# Altium schematic sheet Audio.SchDoc(Audio)
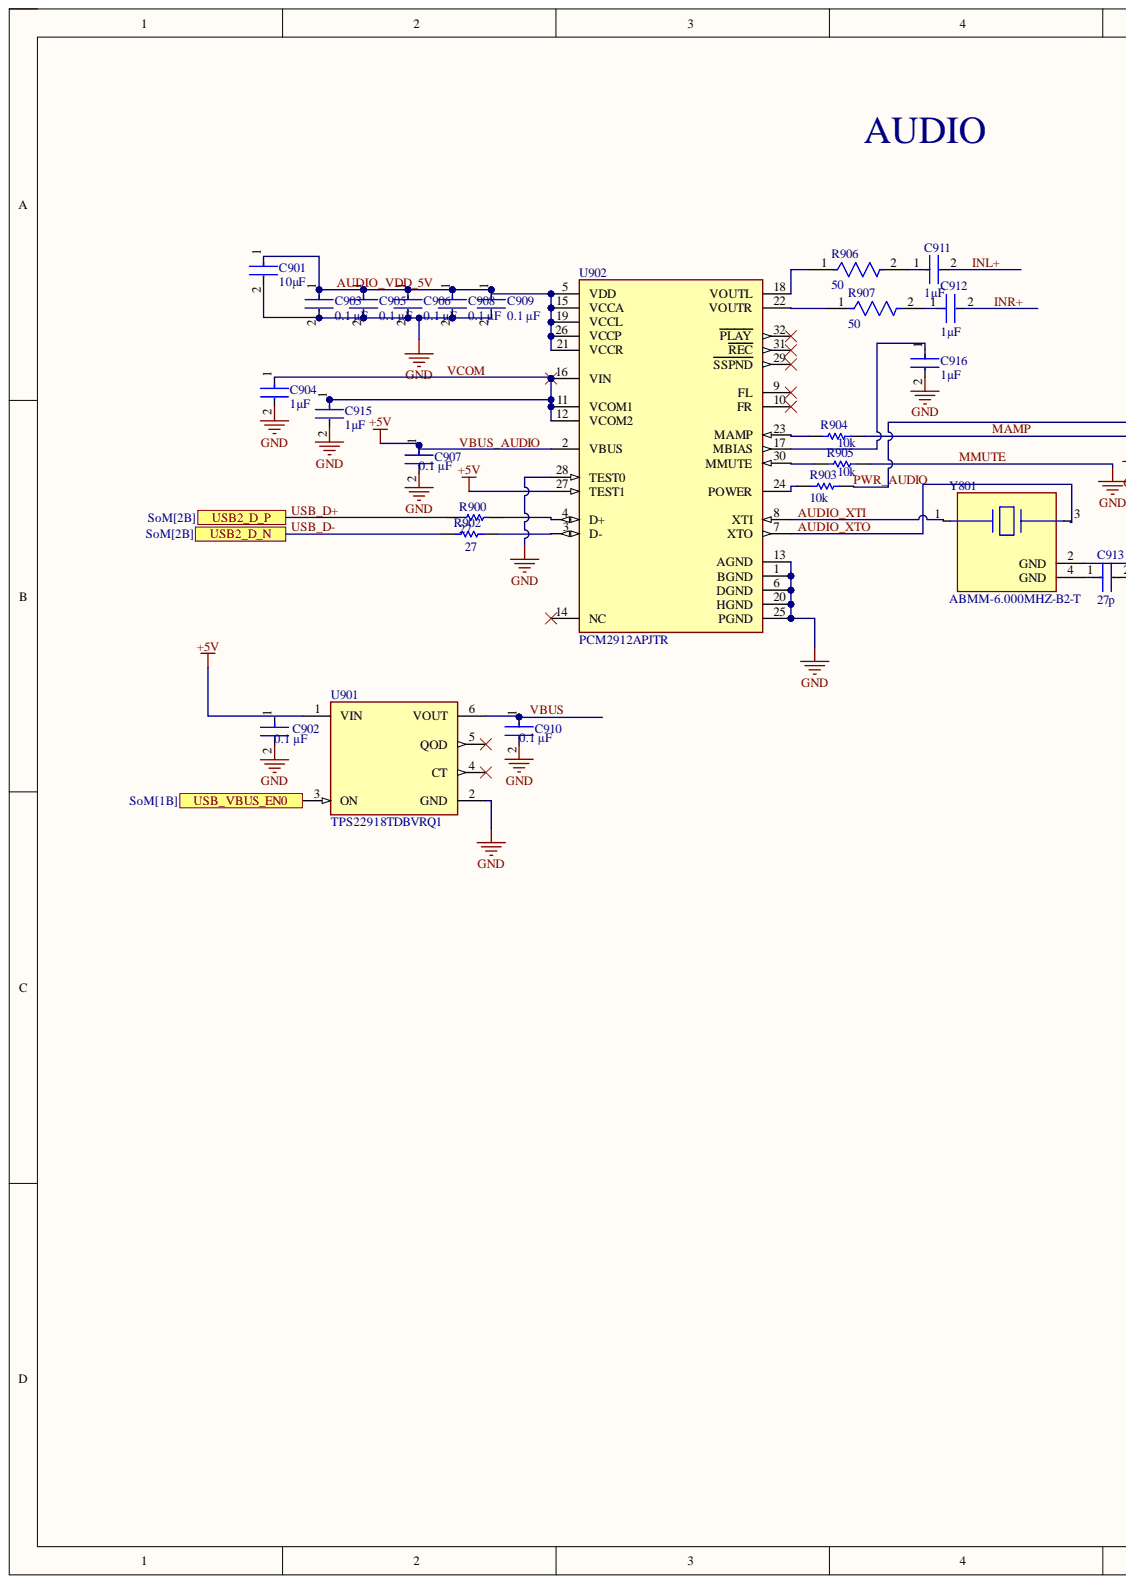
[diagram p0: Audio.SchDoc, left]
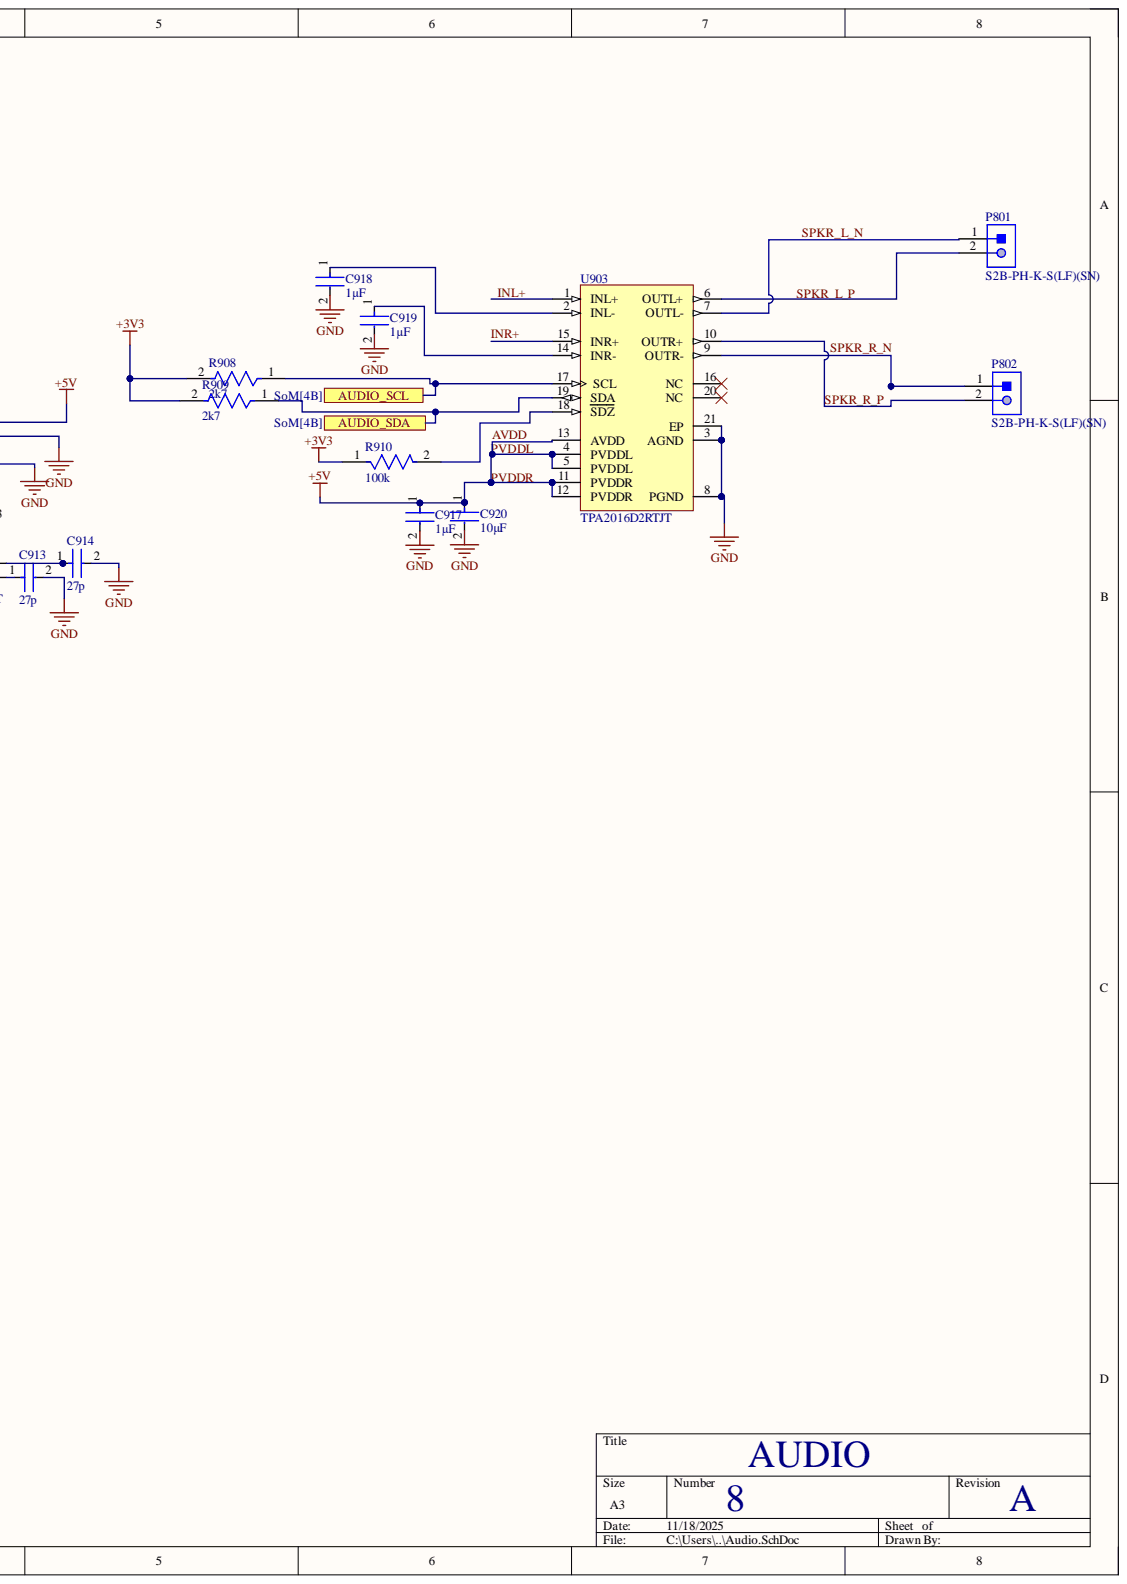
[diagram p1: Audio.SchDoc, right]

## Parts
C901  10μF  [FP-GRM31C-0_2-e0_3_0_8-MFG]
C902  0.1 µF  [FP-GRM155-0_05-IPC_B]
C903  0.1 µF  [FP-GRM155-0_05-IPC_B]
C904  1µF  [FP-GRM15-0_1-MFG]
C905  0.1 µF  [FP-GRM155-0_05-IPC_B]
C906  0.1 µF  [FP-GRM155-0_05-IPC_B]
C907  0.1 µF  [FP-GRM155-0_05-IPC_B]
C908  0.1 µF  [FP-GRM155-0_05-IPC_B]
C909  0.1 µF  [FP-GRM155-0_05-IPC_B]
C910  0.1 µF  [FP-GRM155-0_05-IPC_B]
C911  1µF  [FP-GRM15-0_1-MFG]
C912  1µF  [FP-GRM15-0_1-MFG]
C913  27p  [FP-C0603C-CF-MFG]
C914  27p  [FP-C0603C-CF-MFG]
C915  1µF  [FP-GRM15-0_1-MFG]
C916  1µF  [FP-GRM15-0_1-MFG]
C917  1µF  [FP-GRM15-0_1-MFG]
C918  1µF  [FP-GRM15-0_1-MFG]
C919  1µF  [FP-GRM15-0_1-MFG]
C920  10μF  [FP-GRM31C-0_2-e0_3_0_8-MFG]
P801  S2B-PH-K-S(LF)(SN)  [JST-S2B-PH-K-S_V]
P802  S2B-PH-K-S(LF)(SN)  [JST-S2B-PH-K-S_V]
R900  27  [RESC1005X40X25LL05T10]
R902  27  [RESC1005X40X25LL05T10]
R903  10k  [RESC1005X40X25LL05T10]
R904  10k  [RESC1005X40X25LL05T10]
R905  10k  [RESC1005X40X25LL05T10]
R906  50  [FP-CRCW0603-e3-MFG]
R907  50  [FP-CRCW0603-e3-MFG]
R908  2k7  [FP-CR0402-IPC_B]
R909  2k7  [FP-CR0402-IPC_B]
R910  100k  [FP-RC0402-0_4-IPC_A]
U901  TPS22918TDBVRQ1  [FP-DBV0006A-IPC_C]
U902  PCM2912APJTR  [FP-PJT0032A-IPC_C]
U903  TPA2016D2RTJT  [RTJ0020D_V]
Y801  ABMM-6.000MHZ-B2-T  [FP-ABMM-MFG]

## Ports
AUDIO_SCL, AUDIO_SDA, USB2_D_N, USB2_D_P, USB_VBUS_EN0

## Nets
+3V3: R908.2 R909.2 R910.1
AUDIO_SCL: R908.1 U903.17(SCL) ; port AUDIO_SCL
AUDIO_SDA: R909.1 U903.19(SDA) ; port AUDIO_SDA
AUDIO_VDD_5V: C901.1 C903.1 C905.1 C906.1 C908.1 C909.1 U902.5(VDD) U902.15(VCCA) U902.19(VCCL) U902.21(VCCR) U902.26(VCCP)
AUDIO_XTI: U902.8(XTI) Y801.1
AUDIO_XTO: U902.7(XTO) Y801.3
AVDD: C917.1 C920.1 R903.1 U901.1(VIN) U902.27(TEST1) U903.4(PVDDL) U903.5(PVDDL) U903.11(PVDDR) U903.12(PVDDR) U903.13(AVDD)
INL+: C911.2 U903.1(INL+)
INR+: C912.2 U903.15(INR+)
MAMP: C901.2 C902.2 C903.2 C904.2 C905.2 C906.2 C907.2 C908.2 C909.2 C910.2 C913.2 C914.2 C915.2 C916.2 C917.2 C918.2 C919.2 C920.2 R904.1 R905.1 U901.2(GND) U902.1(BGND) U902.6(DGND) U902.13(AGND) U902.20(HGND) U902.25(PGND) U902.28(TEST0) U903.3(AGND) U903.8(PGND) U903.21(EP)
SPKR_L_N: P801.1 U903.7(OUTL-)
SPKR_R_N: P802.1 U903.9(OUTR-)
SPKR_R_P: P802.2 U903.10(OUTR+)
USB_D+: R900.1 ; port USB2_D_P
USB_D-: R902.1 ; port USB2_D_N
USB_VBUS_EN0: U901.3(ON) ; port USB_VBUS_EN0
VBUS: C910.1 U901.6(VOUT)
VBUS_AUDIO: C907.1 U902.2(VBUS)
VCOM: C904.1 C915.1 U902.11(VCOM1) U902.12(VCOM2) U902.16(VIN)
NetC911_1: C911.1 R906.2
NetC912_1: C912.1 R907.2
NetC913_1: C913.1 Y801.4(GND)
NetC914_1: C914.1 Y801.2(GND)
NetC916_1: C916.1 U902.17(MBIAS)
NetC918_1: C918.1 U903.2(INL-)
NetC919_1: C919.1 U903.14(INR-)
NetP801_2: P801.2 U903.6(OUTL+)
NetR900_2: R900.2 U902.4(D+)
NetR902_2: R902.2 U902.3(D-)
NetR903_2: R903.2 U902.24(POWER)
NetR904_2: R904.2 U902.23(MAMP)
NetR905_2: R905.2 U902.30(MMUTE)
NetR906_1: R906.1 U902.18(VOUTL)
NetR907_1: R907.1 U902.22(VOUTR)
NetR910_2: R910.2 U903.18(SDZ)
SPKR_L_P:

Not connected: C902 1; U901 4(CT) 5(QOD); U902 9(FL) 10(FR) 14(NC) 29(SSPND) 31(REC) 32(PLAY); U903 16(NC) 20(NC)

## Library data of the parts
C901 C920 = CMP-06035-005515-1: Chip Multilayer Ceramic Capacitors for General Purpose, 1206, 10uF, X7R, 15%, 10%, 25V; Capacitance: 10uF; Case/Package: 1206; Component Kind: Standard; Dielectric: X7R; Lead Free: Lead Free; Length: 0.126inch; Library Name: Altium Content Vault; Max Operating Temperature: 125°C; Min Operating Temperature: -55°C; Mount: Surface Mount; Packaging: Tape and Reel; Thickness: 0.071inch; Tolerance: 10%; Voltage: 25V; Voltage Rating: 25V; Voltage Rating (DC): 25V; Width: 0.063inch
C902 C903 C905-C910 = CMP-06035-003750-1: Chip Multilayer Ceramic Capacitors for General Purpose, 0402, 0.10uF, X7R, 15%, 10%, 50V; Capacitance: 100nF; Case Code (Imperial): 0402; Case Code (Metric): 1005; Case/Package: 0402; Component Kind: Standard; Dielectric: X7R; Height: 0.5mm; Lead Free: Lead Free; Length: 0.039inch; Library Name: Altium Content Vault; Max Operating Temperature: 125°C; Min Operating Temperature: -55°C; Mount: Surface Mount; Package Quantity: 50000; Packaging: Tape and Reel; Resistor Type: Ceramic; Termination: SMD/SMT; Thickness: 0.022inch; Tolerance: 10%; Voltage Rating: 50V; Voltage Rating (DC): 50V; Weight: 0.000212oz; Width: 0.5mm
C904 C911 C912 C915-C919 = CMP-2008-04556-2: CAP CER 1UF 10V X5R 0402; Manufacturer: Murata; Manufacturer Part Number: GRM155R61A105KE15D; Material: Ceramic; Temperature Coefficient: 15%; Tolerance: 10%; Automotive: No; Capacitance: 1uF; Category: Cap; Component Kind: Standard; ComponentLink2Description: Datasheet; ComponentLink2URL: https://search.murata.co.jp/Ceramy/image/img/A01X/G101/ENG/GRM155R61A105KE15-01A.pdf; Device Class L1: Passive Components; Device Class L2: Capacitors; Device Class L3: Ceramic Capacitors; Digikey Description: CAP CER 1UF 10V X5R 0402; Height: 0.55mm; Ipc Land Pattern Name: CAPC10050X50; Lead Free: Yes; Library Name: Altium Content Vault; Mouser Description: 0402 1 uF 10 V ±10% Tolerance X5R Surface Mount Multilayer Ceramic Capacitor; Octopart: https://octopart.com/grm155r61a105ke15d-murata-197442; Package: GRM15-0.1; RoHS: TRUE; Temperature: -55°C to +85°C; Temperature Characteristic: X5R; Temperature Range High: +85°C; Temperature Range Low: -55°C; Value: 1uF; Voltage Rating: 10V
C913 C914 = CMP-03020-010139-1: C0603 27 pF X7R 30ppm/°C 5.00% 50 V; Manufacturer: KEMET; Manufacturer Part Number: C0603C270J5RACTU; Automotive Grade: Grade 1; Capacitance: 27 pF; Material: Ceramic; Tolerance: 0.05; Value: 27 pF; Voltage Rating: 50 V; Automotive: Yes; Category: Cap; Component Kind: Standard; ComponentLink2Description: Datasheet; ComponentLink2URL: https://api.kemet.com/component-edge/download/datasheet/C0603C100J5GACAUTO.pdf; Device Class L1: Passive Components; Device Class L2: Capacitors; Device Class L3: Ceramic Capacitors; Height: 0.87mm; Lead Free: Yes; Library Name: Altium Content Vault; Octopart: https://octopart.com/c0603c270j5ractu-kemet-82267354; Package: C0603C-CF; RoHS: TRUE; Temperature: -55°C to +125°C; Temperature Characteristic: X7R; Temperature Coefficient: 30ppm/°C; Temperature Range High: +125°C; Temperature Range Low: -55°C
P801 P802 = CMP-1755-00004-1: Male Header, Pitch 2 mm, 1 x 2 Position, Height 4.8 mm, Tail Length 3.4 mm, -25 to 85 degC, RoHS, Bulk; Capacitance: 10 uF; Case\Package: Radial; Tolerance: 20%; Voltage Rating: 50 V; Component Kind: Standard; ComponentLink1Description: Datasheet; ComponentLink1URL: http://www.jst-mfg.com/product/pdf/eng/ePH.pdf; DatasheetVersion: Dec-12; Diameter: 5 mm; Height: 11 mm; Lead Pitch: 2 mm; Length: 11 mm; Library Name: Altium Content Vault; Max Operating Temperature: 85 degC; Min Operating Temperature: -40 degC; Mount: Through Hole; Package Quantity: 200; PackageDescription: Male Header, Pitch 2 mm, 1 x 2 Position, Height 4.8 mm, Tail Length 3.4 mm; PackageReference: S2B-PH-K-S; Packaging: Bulk; Pins: 2; Radiation Hardening: No; REACH SVHC: No SVHC; RoHSCompliant: true; Voltage Rating (DC): 50 V
R900 R902 = CMP-2002-07055-1: Manufacturer: Yageo / Phycomp; Part Number: RC0402FR-0727RL; Component Kind: Standard; Library Name: Altium Content Vault
R903-R905 = CMP-2002-07353-1: Manufacturer: Yageo / Phycomp; Part Number: RC0402FR-0710KL; Component Kind: Standard; Library Name: Altium Content Vault
R906 R907 = CMP-2000-03436-2: RES Thick Film, 50Ω, 1%, 0.1W, 100ppm/°C, 0603; Power Rating: 100mW; Resistance: 50R; Voltage Rating: 75V; Case Code (Imperial): 0603; Case Code (Metric): 1608; Case/Package: 0603; Component Kind: Standard; Composition: Thick Film; Contact Plating: Tin; Depth: 0.85mm; Height: 0.45mm; Lead Free: Lead Free; Length: 1.55mm; Library Name: Altium Content Vault; Max Operating Temperature: 155°C; Military Standard: Not; Min Operating Temperature: -55°C; Mount: Surface Mount; Number of Pins: 2; Package Quantity: 5000; Packaging: Tape and Reel; Radiation Hardening: No; REACH SVHC: No SVHC; Temperature Coefficient: 100ppm/°C; Termination: SMD/SMT; Tolerance: 1%; Voltage Rating (DC): 75V; Weight: 7.1E-05oz; Width: 0.85mm
R908 R909 = CMP-2002-05009-2: Thick Film Chip Resistors 0402 2.7kΩ 0.0625W 1% 100ppm/°C; Max Power Dissipation: 63mW; Power Rating: 62.5mW; Resistance: 2.7kR; Voltage Rating: 50V; Case Code (Imperial): 0402; Case Code (Metric): 1005; Case/Package: 0402; Component Kind: Standard; Composition: Thick Film; Contact Plating: Tin; Depth: 0.5mm; Height: 0.016inch; Length: 1mm; Library Name: Altium Content Vault; Max Operating Temperature: 125°C; Military Standard: Not; Min Operating Temperature: -55°C; Mount: Surface Mount; Number of Terminations: 2; Package Quantity: 10000; Packaging: Tape and Reel; Radiation Hardening: No; Temperature Coefficient: 100ppm/°C; Termination: SMD/SMT; Thickness: 356um; Tolerance: 1%; Width: 0.02inch
R910 = CMP-2002-07913-2: RES 100K OHM 5% 1/16W 0402; Max Power Dissipation: 63mW; Resistance: 100kR; Temperature Coefficient: 100ppm/°C; Voltage Rating: 50V; Case Code (Imperial): 0402; Case Code (Metric): 1005; Case/Package: 0402; Component Kind: Standard; Construction: Rectangular; Depth: 0.5mm; Features: Moisture Resistant; Height: 0.016inch; Lead Free: Lead Free; Length: 1mm; Library Name: Altium Content Vault; Max Operating Temperature: 155°C; Military Standard: Not; Min Operating Temperature: -55°C; Number of Terminations: 2; Package Quantity: 1; Packaging: Tape and Reel; Power Rating: 63mW; Resistance Tolerance: 5%; Resistor Type: Thick Film; RoHS Compliant: Yes; Series: RC; Size Code: 0402; Termination: SMD/SMT; Tolerance: 5%; Width: 0.02inch
U901 = CMP-04910-000092-1: IC PWR SWITCH N-CHAN 1:1 SOT23-6; Interface: On/Off; Max Output Current: 2A; Number of Channels: 1; Output Configuration: High Side; Case/Package: SOT-23-6; Component Kind: Standard; Lead Free: Lead Free; Library Name: Altium Content Vault; Max Operating Temperature: 105°C; Min Operating Temperature: -40°C; Number of Outputs: 1; Packaging: Tape and Reel; RoHS Compliant: Yes
U902 = CMP-04873-000003-1: IC STEREO AUD CODEC W/USB 32TQFP; Interface: USB; Max Supply Voltage: 5.25V; Min Supply Voltage: 3V; Number of A/D Converters: 1; Number of Analog Outputs: 2; Operating Supply Voltage: 5V; Resolution: 16b; Sampling Rate: 48KS/s; Signal to Noise Ratio (SNR): 92dB; Case/Package: TQFP; Component Kind: Standard; Contact Plating: Gold; Lead Free: Lead Free; Library Name: Altium Content Vault; Max Operating Temperature: 85°C; Min Operating Temperature: -25°C; Mount: Surface Mount; Number of ADC Channels: 1; Number of Analog Inputs: 1; Number of D/A Converters: 1; Number of DAC Channels: 2; Number of Pins: 32; Package Quantity: 1000; Packaging: Tape and Reel; Radiation Hardening: No; RoHS Compliant: Yes; Series: -; Type: General Purpose
U903 = CMP-0991-00197-2: 2.8-W/Ch Stereo Class-D Audio Amplifier with SmartGainTM AGC / DRC, -40 to 85 degC, 20-pin QFN (RTJ20), Green (RoHS & no Sb/Br); Manufacturer: Texas Instruments; Output Power(W): 2.8; PSRR(dB): -80; SubFamily: Dynamic Speaker; Supply Max(Max)(Volt): 5.5; Supply Min(Min)(Volt): 2.5; Component Kind: Standard; ComponentLink1Description: Manufacturer URL; ComponentLink1URL: http://www.ti.com/; ComponentLink2Description: Datasheet; ComponentLink2URL: http://www.ti.com/general/docs/lit/getliterature.tsp?genericPartNumber=TPA2016D2&fileType=pdf; ComponentLink3Description: Package Specification; ComponentLink3URL: http://www.ti.com/litv/pdf/mpqf156e; DatasheetVersion: SLOS524D; Eco-Plan: Green (RoHS & no Sb/Br); Library Name: Altium Content Vault; Mounting Technology: Surface Mount; PackageDescription: 20-pin Plastic Flat Pack, Body 4 x 4 mm, Pitch 0.5 mm; PackageReference: RTJ0020D; PackageVersion: 4205505/F, 07/2011; PartNumber: TPA2016D2RTJT; Speaker Amp Type: Class-D; Speaker Channels: Stereo; Special Features: AGC/DRC
Y801 = CMP-27762-012698-1: CRYSTAL 6.0000MHZ 18PF SMD; ESR (Equivalent Series Resistance): 70R; Frequency: 6MHz; Frequency Stability: 50ppm; Frequency Tolerance: 20ppm; Operating Frequency: 6 MHz; Case/Package: SMD/SMT; Component Kind: Standard; Height: 0.051inch; Lead Free: Lead Free; Length: 0.276inch; Library Name: Altium Content Vault; Load Capacitance: 18pF; Max Operating Temperature: 70°C; Min Operating Temperature: -20°C; Mount: Surface Mount; Number of Pins: 4; Package Quantity: 1000; Packaging: Tape and Reel; Resistor Type: Ceramic; Series: ABMM; Series Resistance: 70R; Termination: SMD/SMT; Tolerance: 20ppm; Width: 5mm
